# Altium schematic sheet temphum.SchDoc
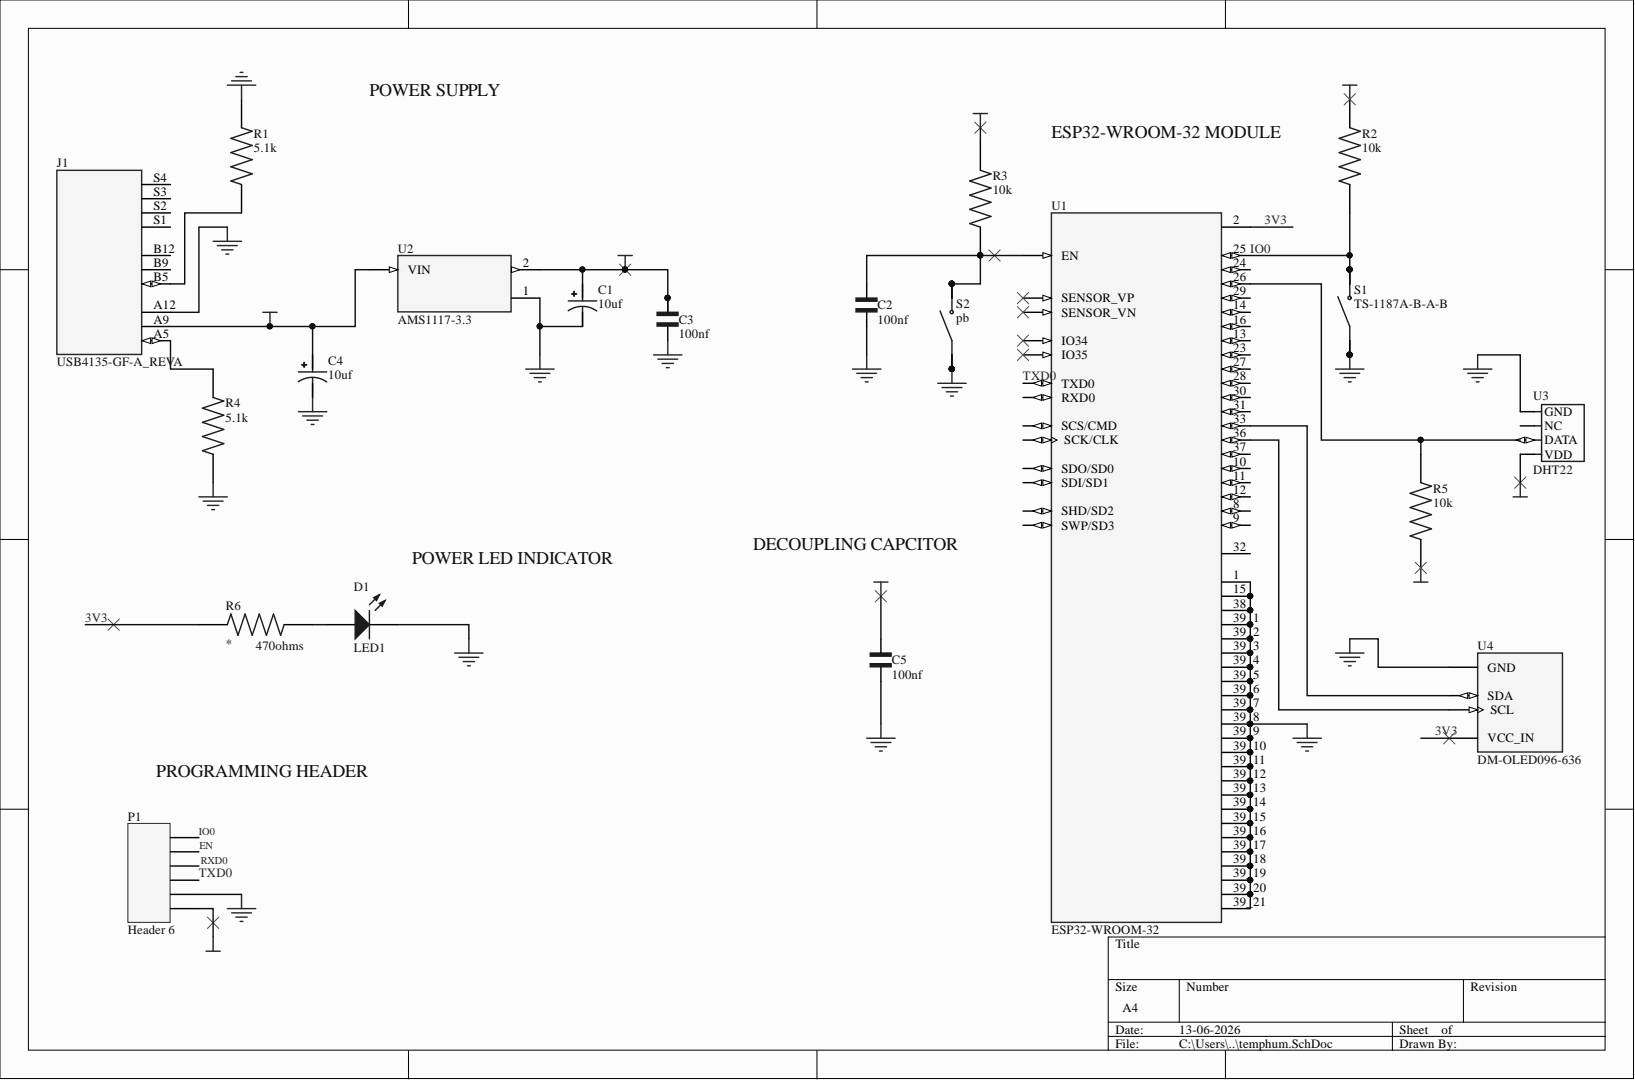
[diagram p0: temphum.SchDoc]

## Parts
C1  10uf  [CAPPM3216X180N]
C2  100nf  [CAPC2012X90N]
C3  100nf  [CAPC2012X90N]
C4  10uf  [CAPPM3216X180N]
C5  100nf  [CAPC2012X90N]
D1  LED1  [LED-1]
J1  USB4135-GF-A_REVA  [GCT_USB4135-GF-A_REVA]
P1  Header 6  [HDR1X6]
R1  5.1k  [RES_ERA6ARB512V]
R2  10k  [RESAD1869W81L1270D457]
R3  10k  [RESAD1869W81L1270D457]
R4  5.1k  [RES_ERA6ARB512V]
R5  10k  [RESAD1869W81L1270D457]
R6  470ohms  [RESC1608X55N]
S1  TS-1187A-B-A-B  [SW_TS-1187A-B-A-B]
S2  pb  [SW_TS-1187A-B-A-B]
U1  ESP32-WROOM-32  [MODULE_ESP32-WROOM-32]
U2  AMS1117-3.3  [SOT229P700X180-4N]
U3  DHT22  [SNR4-2.54-25.0X15.0X7.0MM]
U4  DM-OLED096-636  [MODULE_DM-OLED096-636]

## Nets
5V: C4.1 J1.A9 U2.3
GND: C1.2 C2.1 C3.1 C4.2 C5.1 D1.2 J1.A12 P1.2 R1.2 R4.1 S1.A S1.B S2.A S2.B U1.1 U1.15 U1.38 U1.39_1 U1.39_2 U1.39_3 U1.39_4 U1.39_5 U1.39_6 U1.39_7 U1.39_8 U1.39_9 U1.39_10 U1.39_11 U1.39_12 U1.39_13 U1.39_14 U1.39_15 U1.39_16 U1.39_17 U1.39_18 U1.39_19 U1.39_20 U1.39_21 U2.1 U3.P$4(GND) U4.1(GND)
3V3: C1.1 C3.2 C5.2 P1.1 R2.2 R3.2 R5.2 R6.1 U1.2 U2.2 U3.P$1(VDD) U4.2(VCC_IN)
IO0: P1.6 R2.1 S1.C S1.D U1.25
TXD0: P1.3 U1.35(TXD0)
NetC2_2: C2.2 R3.1 S2.C S2.D U1.3(EN)
NetD1_1: D1.1 R6.2
NetJ1_A5: J1.A5 R4.2
NetJ1_B5: J1.B5 R1.1
NetR5_1: R5.1 U1.26 U3.P$2(DATA)
NetU1_33: U1.33 U4.4(SDA)
NetU1_36: U1.36 U4.3(SCL)
EN:
RXD0:

Not connected: J1 B9 B12 S1 S2 S3 S4; P1 4 5; U1 4(SENSOR_VP) 5(SENSOR_VN) 6(IO34) 7(IO35) 8 9 10 11 12 13 14 16 17(SHD/SD2) 18(SWP/SD3) 19(SCS/CMD) 20(SCK/CLK) 21(SDO/SD0) 22(SDI/SD1) 23 24 27 28 29 30 31 32 34(RXD0) 37; U3 P$3(NC)

## Library data of the parts
C1 C4 = T491A106M010AT: Capacitor;Tantalum;Cap 10uF;Tol 20%;SMT;Vol-Rtg 10V;Tape and Reel | KEMET T491A106M010AT; Check_prices: https://www.snapeda.com/parts/T491A106M010AT/KEMET/view-part/?ref=eda; Component Kind: Standard; Library Name: T491A106M010AT.IntLib; MF: KEMET; MP: T491A106M010AT; Package: SMD-2 KEMET; SnapEDA_Link: https://www.snapeda.com/parts/T491A106M010AT/KEMET/view-part/?ref=snap
C2 C3 C5 = VJ0805Y104KXXCW1BC: Capacitor: ceramic; MLCC; 100nF; 25V; X7R; Â±10%; SMD; 0805; MF: Vishay Vitramon; Check_prices: https://www.snapeda.com/parts/VJ0805Y104KXXCW1BC/Vishay/view-part/?ref=eda; Component Kind: Standard; Library Name: VJ0805Y104KXXCW1BC.IntLib; MP: VJ0805Y104KXXCW1BC; Package: 0805-2 VISHAY; SnapEDA_Link: https://www.snapeda.com/parts/VJ0805Y104KXXCW1BC/Vishay/view-part/?ref=snap
D1 = LED1: Typical RED GaAs LED; Component Kind: Standard; LatestRevisionDate: 08-Jul-2005; LatestRevisionNote: Mech Layer 1 removed.; Library Name: Miscellaneous Devices.IntLib; PackageDescription: LED; 2 Leads; PackageReference: LED-1; Published: 8-Jun-2000; Simulation: LED1; Publisher: Altium Limited
J1 = USB4135-GF-A_REVA: USB CONN, TYPE C, R/A RCPT, 6POS, SMT; USB Connector Type:USB Type C; USB Standard:-; Gender:Receptacle; No. of Positions:6Positions; Connector Mounting:Surface Mount; Orientation:Right Angle; Contact 26AK7776; MF: Global Connector Technology; MP: USB4135GFA; Check_prices: https://www.snapeda.com/parts/USB4135GFA/Global+Connector+Technology/view-part/?ref=eda; Component Kind: Standard; Library Name: USB4135-GF-A_REVA.IntLib; Package: None; SnapEDA_Link: https://www.snapeda.com/parts/USB4135GFA/Global+Connector+Technology/view-part/?ref=snap
P1 = Header 6: Header, 6-Pin; Component Kind: Standard; LatestRevisionDate: 17-Jul-2002; LatestRevisionNote: Re-released for DXP Platform.; Library Name: Miscellaneous Connectors.IntLib; Signal Integrity: Connector; Publisher: Altium Limited
R1 R4 = ERA6ARB512V: Availability: Unavailable; Component Kind: Standard; Library Name: ERA6ARB512V.SchLib; MP: ERA6ARB512V; Package: None; Price: None
R2 R3 R5 = ALSR0310K00JE12: Resistor, WW, ALSR03 10K 5% E12 e3 | Vishay Dale ALSR0310K00JE12; Check_prices: https://www.snapeda.com/parts/ALSR0310K00JE12/Vishay/view-part/?ref=eda; Component Kind: Standard; Library Name: ALSR0310K00JE12.IntLib; MF: Vishay; MP: ALSR0310K00JE12; Package: RADIAL-2 VISHAY; SnapEDA_Link: https://www.snapeda.com/parts/ALSR0310K00JE12/Vishay/view-part/?ref=snap
R6 = CRGP0603F470R: 470 Ohms Â±1% 0.25W, 1/4W Chip Resistor 0603 (1608 Metric) Automotive AEC-Q200, Pulse Withstanding Thick Film; MF: TE Connectivity; Check_prices: https://www.snapeda.com/parts/CRGP0603F470R/TE+Connectivity/view-part/?ref=eda; Component Kind: Standard; Library Name: CRGP0603F470R.SchLib; MP: CRGP0603F470R; Package: 0603-2 TE Connectivity; SnapEDA_Link: https://www.snapeda.com/parts/CRGP0603F470R/TE+Connectivity/view-part/?ref=snap
S1 S2 = TS-1187A-B-A-B: SPST 50mA @ 12VDC Top Actuated Round Button 160gf SMD Tactile Switches RoHS; Availability: Unavailable; Component Kind: Standard; Library Name: TS-1187A-B-A-B.IntLib; MP: TS-1187A-B-A-B; Package: None; Price: None
U1 = ESP32-WROOM-32: Bluetooth, WiFi 802.11b/g/n, Bluetooth v4.2 +EDR, Class 1, 2 and 3 Transceiver Module 2.4GHz ~ 2.5GHz Antenna Not Included, U.FL Surface Mount; MF: Espressif Systems; Availability: In Stock; Check_prices: https://www.snapeda.com/parts/ESP32-WROOM-32/Espressif+Systems/view-part/?ref=eda; Component Kind: Standard; Library Name: ESP32-WROOM-32.IntLib; MP: ESP32-WROOM-32; Package: Module Espressif Systems; Price: None; Purchase-URL: https://pricing.snapeda.com/search/part/ESP32-WROOM-32/?ref=eda; SnapEDA_Link: https://www.snapeda.com/parts/ESP32-WROOM-32/Espressif+Systems/view-part/?ref=snap
U2 = AMS1117-3.3: Sot223/Pkg 1-Amp 3.3-Volt Low Drop Out Voltage Regulatator; MF: Advanced Monolithic Systems; Check_prices: https://www.snapeda.com/parts/AMS1117-3.3/Advanced+Monolithic+Systems/view-part/?ref=eda; Component Kind: Standard; Library Name: AMS1117-3.3.IntLib; MP: AMS1117-3.3; Package: SOT-223 Seeed Technology; SnapEDA_Link: https://www.snapeda.com/parts/AMS1117-3.3/Advanced+Monolithic+Systems/view-part/?ref=snap
U3 = TEM-HUM-AM2302(4P-25.0X15.0MM): AM2302/DHT22 Humidity, Temperature Sensor mikroBUSâ„¢ Clickâ„¢ Platform Evaluation Expansion Board; MF: MCM Electronics; MP: AM2302; Check_prices: https://www.snapeda.com/parts/AM2302/MCM+Electronics/view-part/?ref=eda; Component Kind: Standard; Library Name: TEM-HUM-AM2302(4P-25.0X15.0MM).SchLib; Package: Housed Sensor Seeed Technology; SnapEDA_Link: https://www.snapeda.com/parts/AM2302/MCM+Electronics/view-part/?ref=snap
U4 = DM-OLED096-636: 0.96â€ 128 X 64 MONOCHROME GRAPHIC OLED DISPLAY MODULE - I2C; Check_prices: https://www.snapeda.com/parts/DM-OLED096-636/Display+Module/view-part/?ref=eda; Component Kind: Standard; Library Name: DM-OLED096-636.SchLib; MF: Display Module; MP: DM-OLED096-636; Package: Package; SnapEDA_Link: https://www.snapeda.com/parts/DM-OLED096-636/Display+Module/view-part/?ref=snap
